# Altium schematic sheet IO_Interfaces.SchDoc(IO_Interfaces)
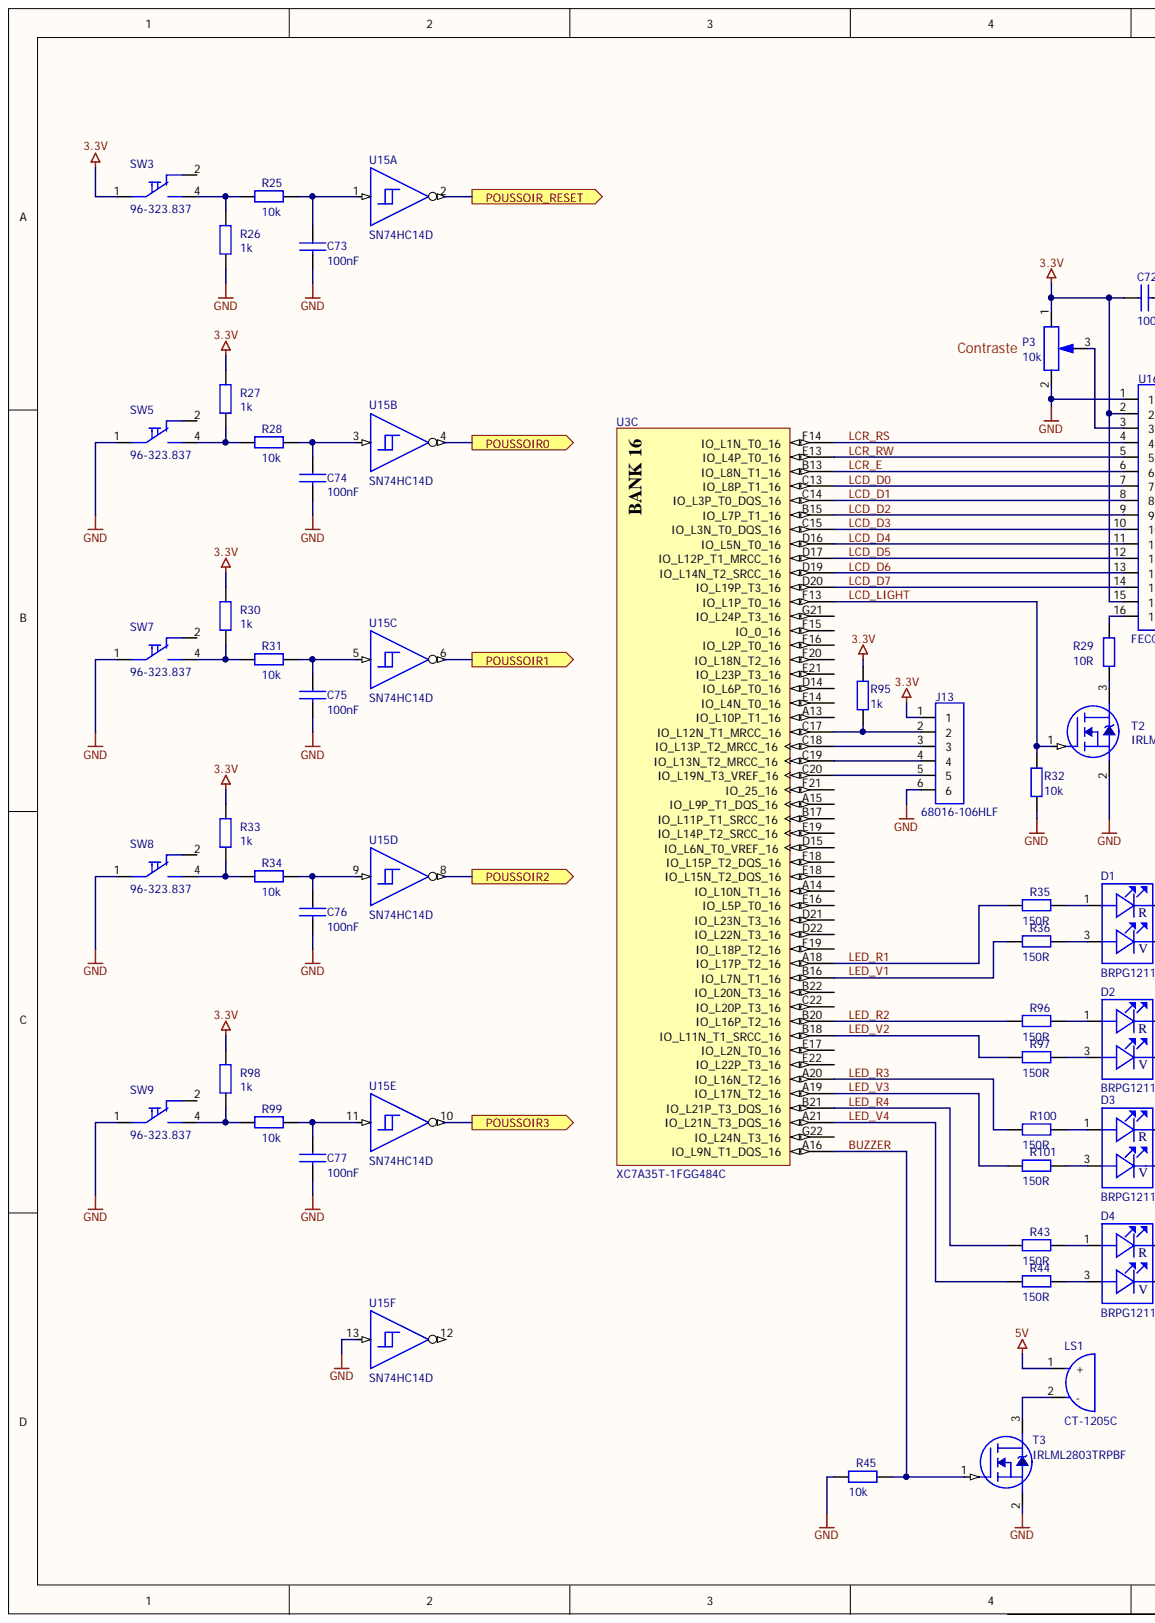
[diagram p0: IO_Interfaces.SchDoc, left]
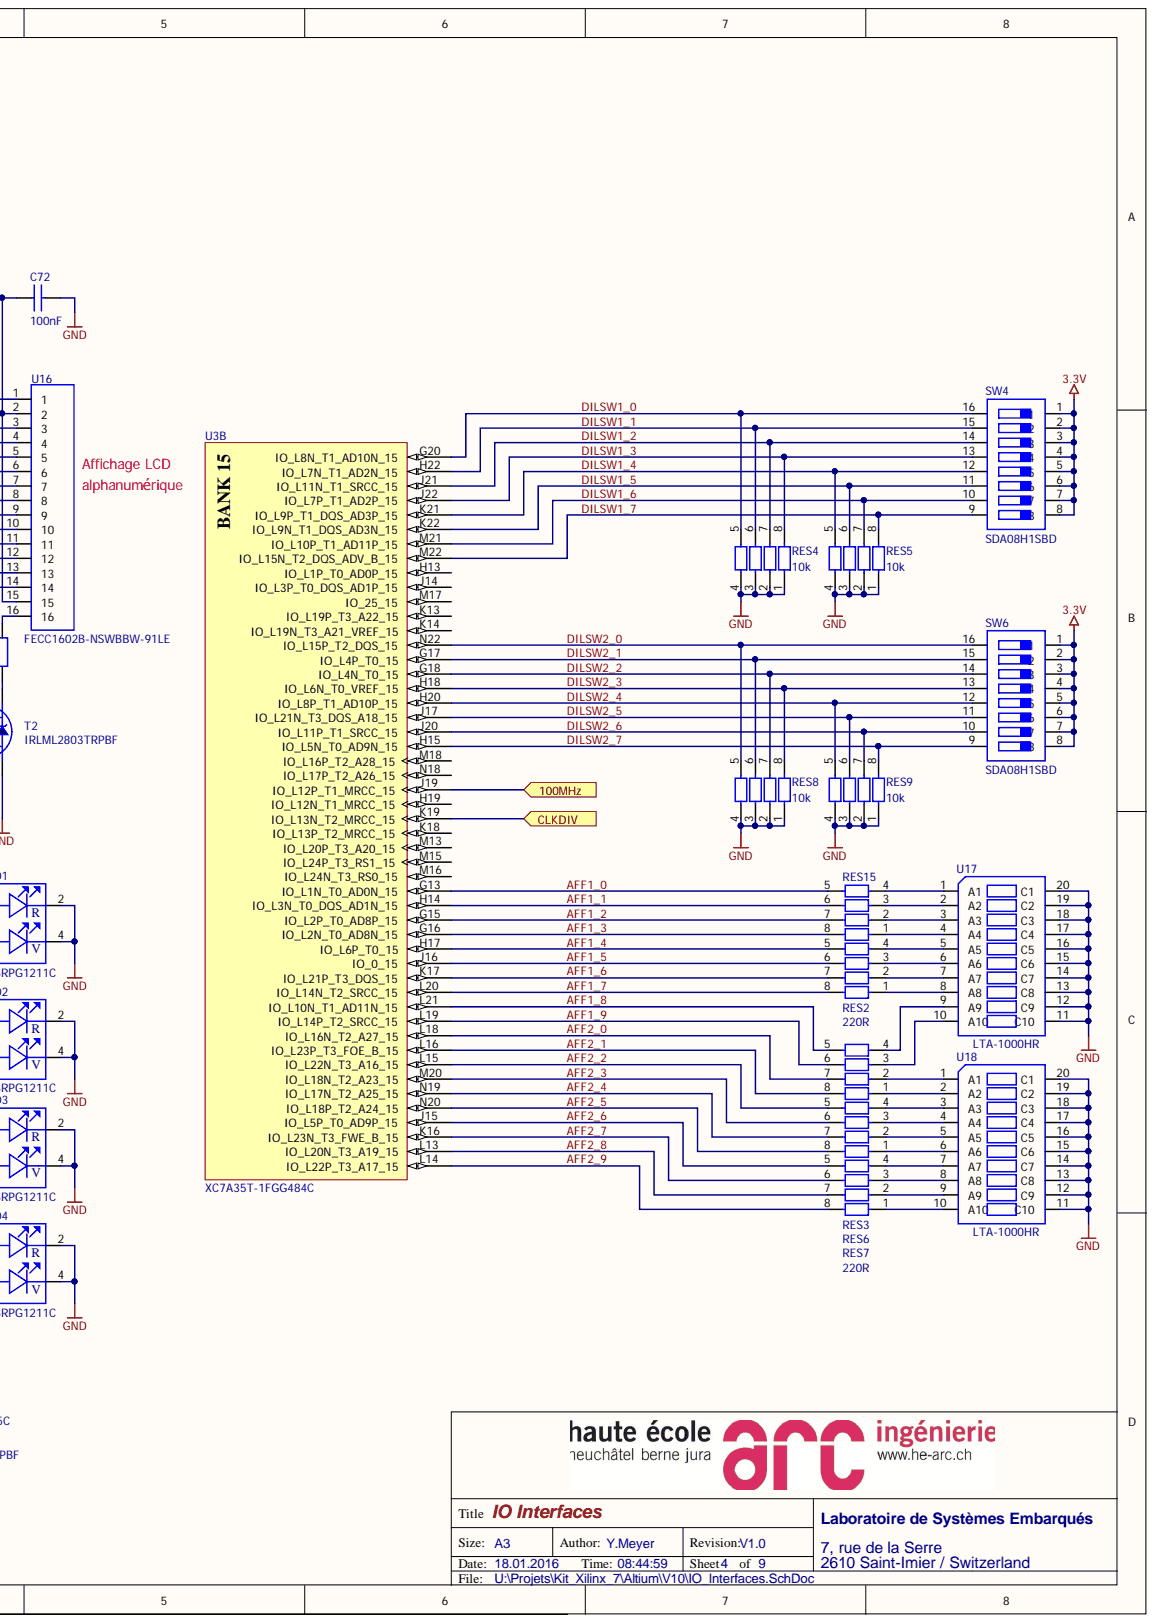
[diagram p1: IO_Interfaces.SchDoc, right]

## Parts
C72  value 100nF  [060301]  (hidden comment: C0603C104Z3VAC7867)
C73  value 100nF  [060301]  (hidden comment: C0603C104Z3VAC7867)
C74  value 100nF  [060301]  (hidden comment: C0603C104Z3VAC7867)
C75  value 100nF  [060301]  (hidden comment: C0603C104Z3VAC7867)
C76  value 100nF  [060301]  (hidden comment: C0603C104Z3VAC7867)
C77  value 100nF  [060301]  (hidden comment: C0603C104Z3VAC7867)
D1  BRPG1211C  [D00701]
D2  BRPG1211C  [D00701]
D3  BRPG1211C  [D00701]
D4  BRPG1211C  [D00701]
J13  68016-106HLF  [J106A101]
LS1  CT-1205C  [BUZZER01]
P3  value 10k  [P00101]  (hidden comment: 3224W-1-103E)
R25  value 10k  [060301]  (hidden comment: RC0603FR-0710KL)
R26  value 1k  [060301]  (hidden comment: RC0603FR-071KL)
R27  value 1k  [060301]  (hidden comment: RC0603FR-071KL)
R28  value 10k  [060301]  (hidden comment: RC0603FR-0710KL)
R29  value 10R  [060301]  (hidden comment: RC0603FR-0710RL)
R30  value 1k  [060301]  (hidden comment: RC0603FR-071KL)
R31  value 10k  [060301]  (hidden comment: RC0603FR-0710KL)
R32  value 10k  [060301]  (hidden comment: RC0603FR-0710KL)
R33  value 1k  [060301]  (hidden comment: RC0603FR-071KL)
R34  value 10k  [060301]  (hidden comment: RC0603FR-0710KL)
R35  value 150R  [060301]  (hidden comment: RC0603FR-07150RL)
R36  value 150R  [060301]  (hidden comment: RC0603FR-07150RL)
R43  value 150R  [060301]  (hidden comment: RC0603FR-07150RL)
R44  value 150R  [060301]  (hidden comment: RC0603FR-07150RL)
R45  value 10k  [060301]  (hidden comment: RC0603FR-0710KL)
R95  value 1k  [060301]  (hidden comment: RC0603FR-071KL)
R96  value 150R  [060301]  (hidden comment: RC0603FR-07150RL)
R97  value 150R  [060301]  (hidden comment: RC0603FR-07150RL)
R98  value 1k  [060301]  (hidden comment: RC0603FR-071KL)
R99  value 10k  [060301]  (hidden comment: RC0603FR-0710KL)
R100  value 150R  [060301]  (hidden comment: RC0603FR-07150RL)
R101  value 150R  [060301]  (hidden comment: RC0603FR-07150RL)
RES2  value 220R  [4060301]  (hidden comment: YC164-JR-07220RL)
RES3  YC164-JR-07220RL  [4060301]  (value not drawn)
RES4  value 10k  [4060301]  (hidden comment: YC164-JR-0710KL)
RES5  value 10k  [4060301]  (hidden comment: YC164-JR-0710KL)
RES6  YC164-JR-07220RL  [4060301]  (value not drawn)
RES7  value 220R  [4060301]  (hidden comment: YC164-JR-07220RL)
RES8  value 10k  [4060301]  (hidden comment: YC164-JR-0710KL)
RES9  value 10k  [4060301]  (hidden comment: YC164-JR-0710KL)
RES15  YC164-JR-07220RL  [4060301]  (value not drawn)
SW3  96-323.837  [SW_EAO]
SW4  SDA08H1SBD  [GDS08]
SW5  96-323.837  [SW_EAO]
SW6  SDA08H1SBD  [GDS08]
SW7  96-323.837  [SW_EAO]
SW8  96-323.837  [SW_EAO]
SW9  96-323.837  [SW_EAO]
T2  IRLML2803TRPBF  [SOT2301]
T3  IRLML2803TRPBF  [SOT2301]
U3  XC7A35T-1FGG484C  [FGG484]  (parts B,C)
U15  SN74HC14D  [SO1401]  (parts A,B,C,D,E,F)
U16  FECC1602B-NSWBBW-91LE  [LCD16x2]
U17  LTA-1000HR  [MV57164]
U18  LTA-1000HR  [MV57164]

## Ports
100MHZ, CLKDIV, POUSSOIR0, POUSSOIR1, POUSSOIR2, POUSSOIR3, POUSSOIR_RESET

## Nets
3.3V: C72.1 J13.1 P3.1 R27.1 R30.1 R33.1 R95.1 R98.1 SW3.1 SW4.1 SW4.2 SW4.3 SW4.4 SW4.5 SW4.6 SW4.7 SW4.8 SW6.1 SW6.2 SW6.3 SW6.4 SW6.5 SW6.6 SW6.7 SW6.8 U15.14 U16.2 U16.15
5V: LS1.1
100MHZ: U3.J19(IO_L12P_T1_MRCC_15) ; port 100MHZ
GND: C72.2 C73.2 C74.2 C75.2 C76.2 C77.2 D1.2 D1.4 D2.2 D2.4 D3.2 D3.4 D4.2 D4.4 J13.6 P3.2 R26.2 R32.1 R45.2 RES4.1 RES4.2 RES4.3 RES4.4 RES5.1 RES5.2 RES5.3 RES5.4 RES8.1 RES8.2 RES8.3 RES8.4 RES9.1 RES9.2 RES9.3 RES9.4 SW5.1 SW7.1 SW8.1 SW9.1 T2.2 T3.2 U15.7 U15.13 U16.1 U17.11(C10) U17.12(C9) U17.13(C8) U17.14(C7) U17.15(C6) U17.16(C5) U17.17(C4) U17.18(C3) U17.19(C2) U17.20(C1) U18.11(C10) U18.12(C9) U18.13(C8) U18.14(C7) U18.15(C6) U18.16(C5) U18.17(C4) U18.18(C3) U18.19(C2) U18.20(C1)
AFF1_0: RES15.5 U3.G13(IO_L1N_T0_AD0N_15)
AFF1_1: RES15.6 U3.H14(IO_L3N_T0_DQS_AD1N_15)
AFF1_2: RES15.7 U3.G15(IO_L2P_T0_AD8P_15)
AFF1_3: RES15.8 U3.G16(IO_L2N_T0_AD8N_15)
AFF1_4: RES2.5 U3.H17(IO_L6P_T0_15)
AFF1_5: RES2.6 U3.J16(IO_0_15)
AFF1_6: RES2.7 U3.K17(IO_L21P_T3_DQS_15)
AFF1_7: RES2.8 U3.L20(IO_L14N_T2_SRCC_15)
AFF1_8: RES3.5 U3.L21(IO_L10N_T1_AD11N_15)
AFF1_9: RES3.6 U3.L19(IO_L14P_T2_SRCC_15)
AFF2_0: RES3.7 U3.L18(IO_L16N_T2_A27_15)
AFF2_1: RES3.8 U3.L16(IO_L23P_T3_FOE_B_15)
AFF2_2: RES6.5 U3.L15(IO_L22N_T3_A16_15)
AFF2_3: RES6.6 U3.M20(IO_L18N_T2_A23_15)
AFF2_4: RES6.7 U3.N19(IO_L17N_T2_A25_15)
AFF2_5: RES6.8 U3.N20(IO_L18P_T2_A24_15)
AFF2_6: RES7.5 U3.J15(IO_L5P_T0_AD9P_15)
AFF2_7: RES7.6 U3.K16(IO_L23N_T3_FWE_B_15)
AFF2_8: RES7.7 U3.L13(IO_L20N_T3_A19_15)
AFF2_9: RES7.8 U3.L14(IO_L22P_T3_A17_15)
BUZZER: R45.1 T3.1 U3.A16(IO_L9N_T1_DQS_16)
CLKDIV: U3.K19(IO_L13N_T2_MRCC_15) ; port CLKDIV
DILSW1_0: RES4.5 SW4.16 U3.G20(IO_L8N_T1_AD10N_15)
DILSW1_1: RES4.6 SW4.15 U3.H22(IO_L7N_T1_AD2N_15)
DILSW1_2: RES4.7 SW4.14 U3.J21(IO_L11N_T1_SRCC_15)
DILSW1_3: RES4.8 SW4.13 U3.J22(IO_L7P_T1_AD2P_15)
DILSW1_4: RES5.5 SW4.12 U3.K21(IO_L9P_T1_DQS_AD3P_15)
DILSW1_5: RES5.6 SW4.11 U3.K22(IO_L9N_T1_DQS_AD3N_15)
DILSW1_6: RES5.7 SW4.10 U3.M21(IO_L10P_T1_AD11P_15)
DILSW1_7: RES5.8 SW4.9 U3.M22(IO_L15N_T2_DQS_ADV_B_15)
DILSW2_0: RES8.5 SW6.16 U3.N22(IO_L15P_T2_DQS_15)
DILSW2_1: RES8.6 SW6.15 U3.G17(IO_L4P_T0_15)
DILSW2_2: RES8.7 SW6.14 U3.G18(IO_L4N_T0_15)
DILSW2_3: RES8.8 SW6.13 U3.H18(IO_L6N_T0_VREF_15)
DILSW2_4: RES9.5 SW6.12 U3.H20(IO_L8P_T1_AD10P_15)
DILSW2_5: RES9.6 SW6.11 U3.J17(IO_L21N_T3_DQS_A18_15)
DILSW2_6: RES9.7 SW6.10 U3.J20(IO_L11P_T1_SRCC_15)
DILSW2_7: RES9.8 SW6.9 U3.H15(IO_L5N_T0_AD9N_15)
LCD_D0: U3.C13(IO_L8P_T1_16) U16.7
LCD_D1: U3.C14(IO_L3P_T0_DQS_16) U16.8
LCD_D2: U3.B15(IO_L7P_T1_16) U16.9
LCD_D3: U3.C15(IO_L3N_T0_DQS_16) U16.10
LCD_D4: U3.D16(IO_L5N_T0_16) U16.11
LCD_D5: U3.D17(IO_L12P_T1_MRCC_16) U16.12
LCD_D6: U3.D19(IO_L14N_T2_SRCC_16) U16.13
LCD_D7: U3.D20(IO_L19P_T3_16) U16.14
LCD_LIGHT: R32.2 T2.1 U3.F13(IO_L1P_T0_16)
LCR_E: U3.B13(IO_L8N_T1_16) U16.6
LCR_RS: U3.F14(IO_L1N_T0_16) U16.4
LCR_RW: U3.E13(IO_L4P_T0_16) U16.5
LED_R1: R35.2 U3.A18(IO_L17P_T2_16)
LED_R2: R96.2 U3.B20(IO_L16P_T2_16)
LED_R3: R100.2 U3.A20(IO_L16N_T2_16)
LED_R4: R43.2 U3.B21(IO_L21P_T3_DQS_16)
LED_V1: R36.2 U3.B16(IO_L7N_T1_16)
LED_V2: R97.2 U3.B18(IO_L11N_T1_SRCC_16)
LED_V3: R101.2 U3.A19(IO_L17N_T2_16)
LED_V4: R44.2 U3.A21(IO_L21N_T3_DQS_16)
POUSSOIR0: U15.4 ; port POUSSOIR0
POUSSOIR1: U15.6 ; port POUSSOIR1
POUSSOIR2: U15.8 ; port POUSSOIR2
POUSSOIR3: U15.10 ; port POUSSOIR3
POUSSOIR_RESET: U15.2 ; port POUSSOIR_RESET
NetC73_1: C73.1 R25.1 U15.1
NetC74_1: C74.1 R28.1 U15.3
NetC75_1: C75.1 R31.1 U15.5
NetC76_1: C76.1 R34.1 U15.9
NetC77_1: C77.1 R99.1 U15.11
NetD1_1: D1.1 R35.1
NetD1_3: D1.3 R36.1
NetD2_1: D2.1 R96.1
NetD2_3: D2.3 R97.1
NetD3_1: D3.1 R100.1
NetD3_3: D3.3 R101.1
NetD4_1: D4.1 R43.1
NetD4_3: D4.3 R44.1
NetJ13_2: J13.2 R95.2 U3.C17(IO_L12N_T1_MRCC_16)
NetJ13_3: J13.3 U3.C18(IO_L13P_T2_MRCC_16)
NetJ13_4: J13.4 U3.C19(IO_L13N_T2_MRCC_16)
NetJ13_5: J13.5 U3.C20(IO_L19N_T3_VREF_16)
NetLS1_2: LS1.2 T3.3
NetP3_3: P3.3 U16.3
NetR25_2: R25.2 R26.1 SW3.4
NetR27_2: R27.2 R28.2 SW5.4
NetR29_1: R29.1 U16.16
NetR29_2: R29.2 T2.3
NetR30_2: R30.2 R31.2 SW7.4
NetR33_2: R33.2 R34.2 SW8.4
NetR98_2: R98.2 R99.2 SW9.4
NetRES2_1: RES2.1 U17.8(A8)
NetRES2_2: RES2.2 U17.7(A7)
NetRES2_3: RES2.3 U17.6(A6)
NetRES2_4: RES2.4 U17.5(A5)
NetRES3_1: RES3.1 U18.2(A2)
NetRES3_2: RES3.2 U18.1(A1)
NetRES3_3: RES3.3 U17.10(A10)
NetRES3_4: RES3.4 U17.9(A9)
NetRES6_1: RES6.1 U18.6(A6)
NetRES6_2: RES6.2 U18.5(A5)
NetRES6_3: RES6.3 U18.4(A4)
NetRES6_4: RES6.4 U18.3(A3)
NetRES7_1: RES7.1 U18.10(A10)
NetRES7_2: RES7.2 U18.9(A9)
NetRES7_3: RES7.3 U18.8(A8)
NetRES7_4: RES7.4 U18.7(A7)
NetRES15_1: RES15.1 U17.4(A4)
NetRES15_2: RES15.2 U17.3(A3)
NetRES15_3: RES15.3 U17.2(A2)
NetRES15_4: RES15.4 U17.1(A1)

Not connected: SW3 2; SW5 2; SW7 2; SW8 2; SW9 2; U3 A13(IO_L10P_T1_16) A14(IO_L10N_T1_16) A15(IO_L9P_T1_DQS_16) B17(IO_L11P_T1_SRCC_16) B22(IO_L20N_T3_16) C22(IO_L20P_T3_16) D14(IO_L6P_T0_16) D15(IO_L6N_T0_VREF_16) D21(IO_L23N_T3_16) D22(IO_L22N_T3_16) E14(IO_L4N_T0_16) E16(IO_L5P_T0_16) E17(IO_L2N_T0_16) E18(IO_L15N_T2_DQS_16) E19(IO_L14P_T2_SRCC_16) E21(IO_L23P_T3_16) E22(IO_L22P_T3_16) F15(IO_0_16) F16(IO_L2P_T0_16) F18(IO_L15P_T2_DQS_16) F19(IO_L18P_T2_16) F20(IO_L18N_T2_16) F21(IO_25_16) G21(IO_L24P_T3_16) G22(IO_L24N_T3_16) H13(IO_L1P_T0_AD0P_15) H19(IO_L12N_T1_MRCC_15) J14(IO_L3P_T0_DQS_AD1P_15) K13(IO_L19P_T3_A22_15) K14(IO_L19N_T3_A21_VREF_15) K18(IO_L13P_T2_MRCC_15) M13(IO_L20P_T3_A20_15) M15(IO_L24P_T3_RS1_15) M16(IO_L24N_T3_RS0_15) M17(IO_25_15) M18(IO_L16P_T2_A28_15) N18(IO_L17P_T2_A26_15); U15 12

## Library data of the parts
C72-C77 = CAPA: CAP .10UF 25V CERAMIC Y5V 0603; Component Kind: Standard; Supplier 1: Digi-Key; Supplier Part Number 1: 399-1100-2-ND; Library Name: EISI.SchLib
D1-D4 = LED_BIC3: LED RED/GREEN BI-COLOR SMD; Component Kind: Standard; Supplier 1: Digi-Key; Supplier Part Number 1: 404-1086-6-ND; Library Name: EISI.SchLib
J13 = CON6: CONN HEADER 6POS .100 R/A 30AU; Component Kind: Standard; Supplier 1: Digi-Key; Supplier Part Number 1: 609-3313-ND; Library Name: EISI.SchLib
LS1 = Buzzer: BUZZER MAGNET 12.8MM 4-7VDC SMD; Component Kind: Standard; Revision: July-2002: Re-released for DXP Platform.; Supplier 1: Digi-Key; Supplier Part Number 1: 102-1200-1-ND; Library Name: EISI.SchLib
P3 = POT: TRIMPOT 10K OHM 4MM TOP ADJ SMD; Component Kind: Standard; Supplier 1: Digi-Key; Supplier Part Number 1: 3224W-103ETR-ND; Library Name: EISI.SchLib
R25 R28 R31 R32 R34 R45 R99 = RES: RES 10.0K OHM 1/10W 1% 0603 SMD; Component Kind: Standard; Supplier 1: Digi-Key; Supplier Part Number 1: 311-10.0KHRCT-ND; Library Name: EISI.SchLib
R26 R27 R30 R33 R95 R98 = RES: RES SMD 1K OHM 1% 1/10W 0603; Component Kind: Standard; Supplier 1: Digi-Key; Supplier Part Number 1: 311-1.00KHRCT-ND; Library Name: EISI.SchLib
R29 = RES: RES SMD 10 OHM 1% 1/10W 0603; Component Kind: Standard; Supplier 1: Digi-Key; Supplier Part Number 1: 311-10.0HRCT-ND; Library Name: EISI.SchLib
R35 R36 R43 R44 R96 R97 R100 R101 = RES: RES 150 OHM 1/10W 1% 0603 SMD; Component Kind: Standard; Supplier 1: Digi-Key; Supplier Part Number 1: 311-150HRTR-ND; Library Name: EISI.SchLib
RES2 RES7 = RESEAU_4R: RES ARRAY 4 RES 220 OHM 1206; Component Kind: Standard; Supplier 1: Digi-Key; Supplier Part Number 1: YC164J-220TR-ND; Library Name: EISI.SchLib
RES3 RES6 RES15 = RESEAU_4R: RES ARRAY 4 RES 220 OHM 1206; Value: 220R; Component Kind: Standard; Supplier 1: Digi-Key; Supplier Part Number 1: YC164J-220TR-ND; Library Name: EISI.SchLib
RES4 RES5 RES8 RES9 = RESEAU_4R: RES ARRAY 4 RES 10K OHM 1206; Component Kind: Standard; Supplier 1: Digi-Key; Supplier Part Number 1: YC164J-10KTR-ND; Library Name: EISI.SchLib
SW3 SW5 SW7-SW9 = SW_EAO: Bouton poussoir EAO; Component Kind: Standard; Supplier 1: Mouser; Supplier Part Number 1: 123-96-323.837; Library Name: EISI.SchLib
SW4 SW6 = SW DIP-8: SWITCH DIP TOP SLIDE 8POS SMD; Component Kind: Standard; Supplier 1: Digi-Key; Supplier Part Number 1: CKN6092-ND; Library Name: EISI.SchLib
T2 T3 = IRLML2803: MOSFET N-CH 30V 1.2A SOT-23; Component Kind: Standard; Supplier 1: Digi-Key; Supplier Part Number 1: IRLML2803PBFTR-ND; Library Name: EISI.SchLib
U3 = XC7A35T-1FGG484C: Artix-7 FPGA, 250 User I/Os, 4 GTP, 484-Ball BGA, Speed Grade 1, Commercial Grade, Pb-Free; Manufacturer 1: Xilinx; Component Kind: Standard; Library Name: KitXilinx7.SCHLIB; Manufacturer Part Number 1: XC7A35T-1FGG484C; Supplier 1: Avnet Express; Supplier Part Number 1: XC7A35T-1FGG484C; Supplier Subtotal 1: 2140; Supplier Unit Price 1: 52.1952
U15 = SN74AHC14D: IC HEX SCHMITT-TRIG INV 14-SOIC; Component Kind: Standard; Supplier 1: Digi-Key; Supplier Part Number 1: 296-1194-5-ND; Library Name: EISI.SchLib
U16 = CON16: LCD display 16x2 => monter barettes femelle sur PCB; Component Kind: Standard; Supplier 1: Farnell; Supplier Part Number 1: 1847938; Library Name: EISI.SchLib
U17 U18 = MV57164: LED BAR GRAPH 10-SEGMENT RED; Component Kind: Standard; Supplier 1: Digi-Key; Supplier Part Number 1: 160-1066-ND; Library Name: EISI.SchLib
